# Altium schematic sheet sEMG_SignalAquisition.SchDoc
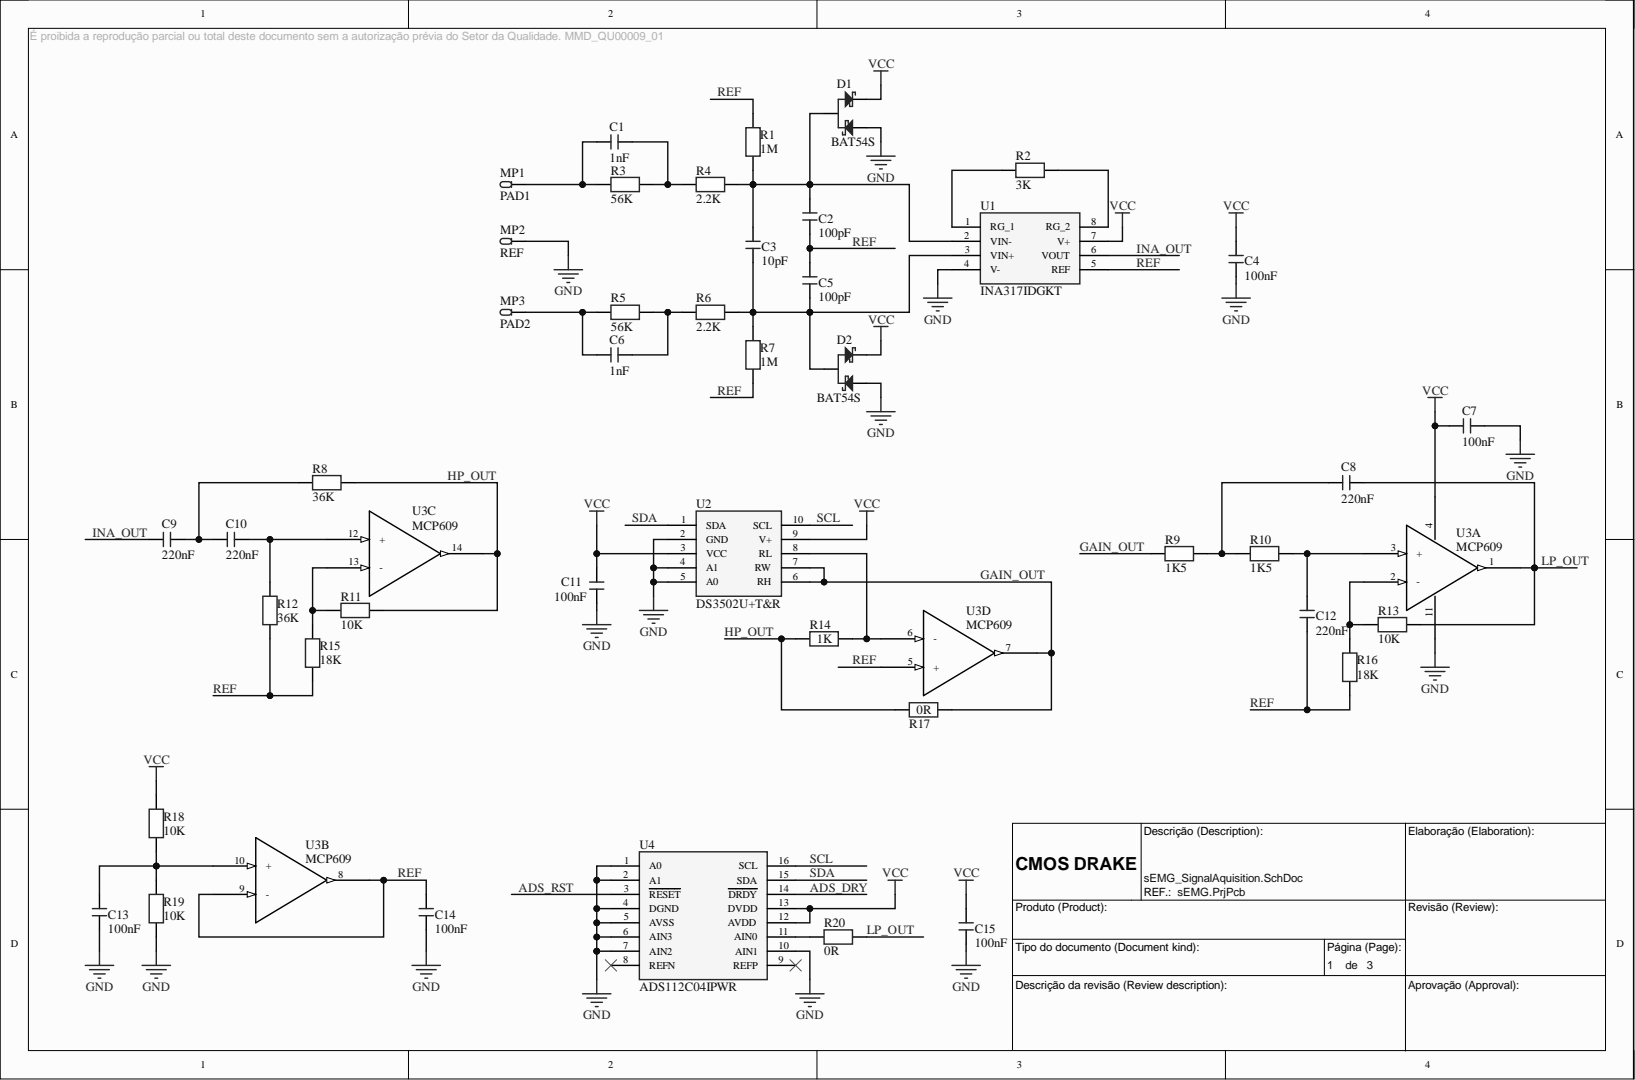
[diagram p0: sEMG_SignalAquisition.SchDoc]

## Parts
C1  1nF  [CAP0805[2012]MD]
C2  100pF  [CAP0805[2012]MD]
C3  10pF  [CAP0805[2012]MD]
C4  100nF  [CAP0603[1608]MD-BO]
C5  100pF  [CAP0805[2012]MD]
C6  1nF  [CAP0805[2012]MD]
C7  100nF  [CAP0603[1608]MD-BO]
C8  220nF  [CAP0805[2012]MD]
C9  220nF  [CAP0805[2012]MD]
C10  220nF  [CAP0805[2012]MD]
C11  100nF  [CAP0603[1608]MD-BO]
C12  220nF  [CAP0805[2012]MD]
C13  100nF  [CAP0603[1608]MD-BO]
C14  100nF  [CAP0603[1608]MD-BO]
C15  100nF  [CAP0603[1608]MD-BO]
D1  BAT54S  [SOT233MD]
D2  BAT54S  [SOT233MD]
MP1  PAD1  [MP1.6MM-BLACK]
MP2  REF  [MP1.6MM-BLACK]
MP3  PAD2  [MP1.6MM-BLACK]
R1  1M  [RES0805[2012]MD]
R2  3K  [RES0805[2012]MD]
R3  56K  [RES0805[2012]MD]
R4  2.2K  [RES0805[2012]MD]
R5  56K  [RES0805[2012]MD]
R6  2.2K  [RES0805[2012]MD]
R7  1M  [RES0805[2012]MD]
R8  36K  [RES0805[2012]MD]
R9  1K5  [RES0805[2012]MD]
R10  1K5  [RES0805[2012]MD]
R11  10K  [RES0805[2012]MD]
R12  36K  [RES0805[2012]MD]
R13  10K  [RES0805[2012]MD]
R14  1K  [RES0805[2012]MD]
R15  18K  [RES0805[2012]MD]
R16  18K  [RES0805[2012]MD]
R17  0R  [RES0603[1608]MD-BO]
R18  10K  [RES0805[2012]MD]
R19  10K  [RES0805[2012]MD]
R20  0R  [RES0603[1608]MD-BO]
U1  INA317IDGKT  [SOP65P490X110-8N]
U2  DS3502U+T&R  [SOP50P490X110-10N]
U3  MCP609  [SOP65P638X110-14N]  (parts A,B,C,D)
U4  ADS112C04IPWR  [SOP65P640X120-16N]

## Nets
GND: C4.2 C7.2 C11.2 C13.2 C14.2 C15.2 D1.1 D2.1 MP2.1 R19.2 U1.4(V-) U2.2(GND) U2.4(A1) U2.5(A0) U3.11 U4.1(A0) U4.2(A1) U4.4(DGND) U4.5(AVSS) U4.6(AIN3) U4.7(AIN2) U4.10(AIN1)
VCC: C4.1 C7.1 C11.1 C15.1 D1.2 D2.2 R18.1 U1.7(V+) U2.3(VCC) U2.9(V+) U3.4 U4.12(AVDD) U4.13(DVDD)
ADS_DRY: U4.14(DRDY)
ADS_RST: U4.3(RESET)
GAIN_OUT: R9.2 R17.2 U2.6(RH) U2.7(RW) U3.7
HP_OUT: R8.1 R11.1 R14.1 R17.1 U3.14
INA_OUT: C9.1 U1.6(VOUT)
LP_OUT: C8.2 R13.1 R20.2 U3.1
REF: C2.2 C5.1 C12.1 C14.1 R1.2 R7.1 R12.1 R15.1 R16.1 U1.5(REF) U3.5(+) U3.8 U3.9(-)
SCL: U2.10(SCL) U4.16(SCL)
SDA: U2.1(SDA) U4.15(SDA)
NetC1_1: C1.1 MP1.1 R3.1
NetC1_2: C1.2 R3.2 R4.1
NetC2_1: C2.1 C3.1 D1.3 R1.1 R4.2 U1.2(VIN-)
NetC3_2: C3.2 C5.2 D2.3 R6.2 R7.2 U1.3(VIN+)
NetC6_1: C6.1 MP3.1 R5.1
NetC6_2: C6.2 R5.2 R6.1
NetC8_1: C8.1 R9.1 R10.2
NetC9_2: C9.2 C10.2 R8.2
NetC10_1: C10.1 R12.2 U3.12(+)
NetC12_2: C12.2 R10.1 U3.3(+)
NetC13_1: C13.1 R18.2 R19.1 U3.10(+)
NetR2_1: R2.1 U1.1(RG_1)
NetR2_2: R2.2 U1.8(RG_2)
NetR11_2: R11.2 R15.2 U3.13(-)
NetR13_2: R13.2 R16.2 U3.2(-)
NetR14_2: R14.2 U2.8(RL) U3.6(-)
NetR20_1: R20.1 U4.11(AIN0)

Not connected: U4 8(REFN) 9(REFP)

## Library data of the parts
C1 C6 = SMD 0805 1nF 50V 5% NPO: CERAMIC SMD 0805 1nF 50V 5% NP0; Manufacturer 1: TDK; Part Number 1: C2012C0G1H102J; Component Kind: Standard; Library Name: Capacitors.SCHLIB
C2 C5 = SMD 0805 10pF 50V 5% C0G: CERAMIC SMD 0805 100pF 50V 5% C0G; Manufacturer 1: Johanson Dielectrics Inc; Part Number 1: 500R15N100JV4T; Component Kind: Standard; Library Name: Capacitors.SCHLIB; Manufacturer 2: Samsung Electro-Mechanics America, Inc; Manufacturer 3: Samsung Electro-Mechanics America, Inc; Manufacturer 4: Kemet; Manufacturer 5: Yageo; Manufacturer 6: AVX Corporation; Part Number 2: CL21C100JBANNNC; Part Number 3: CL21C100JBANFNC; Part Number 4: C0805C100J5GACTU; Part Number 5: CC0805JRNPO9BN100; Part Number 6: 08055A100JAT2A
C3 = SMD 0805 10pF 50V 5% C0G: CERAMIC SMD 0805 10pF 50V 5% C0G; Manufacturer 1: Johanson Dielectrics Inc; Part Number 1: 500R15N100JV4T; Component Kind: Standard; Library Name: Capacitors.SCHLIB; Manufacturer 2: Samsung Electro-Mechanics America, Inc; Manufacturer 3: Samsung Electro-Mechanics America, Inc; Manufacturer 4: Kemet; Manufacturer 5: Yageo; Manufacturer 6: AVX Corporation; Part Number 2: CL21C100JBANNNC; Part Number 3: CL21C100JBANFNC; Part Number 4: C0805C100J5GACTU; Part Number 5: CC0805JRNPO9BN100; Part Number 6: 08055A100JAT2A
C4 C7 C11 C13-C15 = SMD 0603 100nF 50V 5% X7R: CERAMIC SMD 0603 100nF 50V 5% X7R; Manufacturer 1: Samsung Electro-Mechanics America, Inc.; Part Number 1: CL10B104JB8NNNC; Component Kind: Standard; Library Name: Capacitors.SCHLIB; Manufacturer 2: Yageo; Manufacturer 3: AVX Corporation; Manufacturer 4: Murata Electronics North America; Manufacturer 5: KEMET; Part Number 2: CC0603JRX7R9BB104; Part Number 3: 06035C104JAT2A; Part Number 4: GRM188R71H104JA93D; Part Number 5: C0603C104J5RACTU
C8-C10 C12 = SMD 0805 220nF 50V 10% X7R: CERAMIC SMD 0805 220nF 50V 10% X7R; Manufacturer 1: Taiyo Yuden; Part Number 1: UMK212B7224KG-T; Component Kind: Standard; Library Name: Capacitors.SCHLIB; Manufacturer 2: Samsung Electro-Mechanics America, Inc; Manufacturer 3: Kemet; Manufacturer 4: TDK Corporation; Part Number 2: CL21B224KBFSFNE; Part Number 3: C0805C224K5RACTU; Part Number 4: C2012X7R1H224K125AA
D1 D2 = SCHOTTKY DUPLO S 30V 0.2A: Manufacturer 1: Vishay; Part Number 1: BAT54S-E3-08; Component Kind: Standard; Description: SCHOTTKY DUPLO S 30V 0.2A; Library Name: Diodes.SchLib; Manufacturer 2: MCC; Manufacturer 3: Comchip; Part Number 2: BAT54S-TP; Part Number 3: BAT54S-G
MP1-MP3 = MP PTH MULTI PURPOSE 1.6 MM BLACK: MULTI PTH PURPOSE MEASURE BLACK; Manufacturer 1: Keystone Electronics; Part Number 1: 5011; Component Kind: Standard; Library Name: Miscellaneous.SchLib
R1 R7 = SMD 0805 10K 5% 0.125W: RESISTOR SMD 0805 1M 1% 0.25W; Manufacturer 1: Yageo; Part Number 1: RC0805JR-0710KL; Component Kind: Standard; Library Name: Resistors.SCHLIB; Manufacturer 2: Samsung Electro-Mechanics America, Inc; Manufacturer 3: Stackpole Electronics Inc; Manufacturer 4: Rohm Semiconductor; Part Number 2: RC2012J103CS; Part Number 3: RMCF0805JT10K0; Part Number 4: MCR10ERTJ103
R2 = SMD 0805 10K 5% 0.125W: RESISTOR SMD 0805 3K 5% 0.25W; Manufacturer 1: Yageo; Part Number 1: RC0805JR-0710KL; Component Kind: Standard; Library Name: Resistors.SCHLIB; Manufacturer 2: Samsung Electro-Mechanics America, Inc; Manufacturer 3: Stackpole Electronics Inc; Manufacturer 4: Rohm Semiconductor; Part Number 2: RC2012J103CS; Part Number 3: RMCF0805JT10K0; Part Number 4: MCR10ERTJ103
R3 R5 = SMD 0805 10K 5% 0.125W: RESISTOR SMD 0805 56K 5% 0.25W; Manufacturer 1: Yageo; Part Number 1: RC0805JR-0710KL; Component Kind: Standard; Library Name: Resistors.SCHLIB; Manufacturer 2: Samsung Electro-Mechanics America, Inc; Manufacturer 3: Stackpole Electronics Inc; Manufacturer 4: Rohm Semiconductor; Part Number 2: RC2012J103CS; Part Number 3: RMCF0805JT10K0; Part Number 4: MCR10ERTJ103
R4 R6 = SMD 0805 10K 5% 0.125W: RESISTOR SMD 0805 2.2K 5% 0.25W; Manufacturer 1: Yageo; Part Number 1: RC0805JR-0710KL; Component Kind: Standard; Library Name: Resistors.SCHLIB; Manufacturer 2: Samsung Electro-Mechanics America, Inc; Manufacturer 3: Stackpole Electronics Inc; Manufacturer 4: Rohm Semiconductor; Part Number 2: RC2012J103CS; Part Number 3: RMCF0805JT10K0; Part Number 4: MCR10ERTJ103
R8 R12 = SMD 0805 10K 5% 0.125W: RESISTOR SMD 0805 36K 1% 0.25W; Manufacturer 1: Yageo; Part Number 1: RC0805JR-0710KL; Component Kind: Standard; Library Name: Resistors.SCHLIB; Manufacturer 2: Samsung Electro-Mechanics America, Inc; Manufacturer 3: Stackpole Electronics Inc; Manufacturer 4: Rohm Semiconductor; Part Number 2: RC2012J103CS; Part Number 3: RMCF0805JT10K0; Part Number 4: MCR10ERTJ103
R9 R10 = SMD 0805 10K 5% 0.125W: RESISTOR SMD 0805 1K5 1% 0.25W; Manufacturer 1: Yageo; Part Number 1: RC0805JR-0710KL; Component Kind: Standard; Library Name: Resistors.SCHLIB; Manufacturer 2: Samsung Electro-Mechanics America, Inc; Manufacturer 3: Stackpole Electronics Inc; Manufacturer 4: Rohm Semiconductor; Part Number 2: RC2012J103CS; Part Number 3: RMCF0805JT10K0; Part Number 4: MCR10ERTJ103
R11 R13 R18 R19 = SMD 0805 10K 5% 0.125W: RESISTOR SMD 0805 10K 1% 0.25W; Manufacturer 1: Yageo; Part Number 1: RC0805JR-0710KL; Component Kind: Standard; Library Name: Resistors.SCHLIB; Manufacturer 2: Samsung Electro-Mechanics America, Inc; Manufacturer 3: Stackpole Electronics Inc; Manufacturer 4: Rohm Semiconductor; Part Number 2: RC2012J103CS; Part Number 3: RMCF0805JT10K0; Part Number 4: MCR10ERTJ103
R14 = SMD 0805 10K 5% 0.125W: RESISTOR SMD 0805 1K 5% 0.25W; Manufacturer 1: Yageo; Part Number 1: RC0805JR-0710KL; Component Kind: Standard; Library Name: Resistors.SCHLIB; Manufacturer 2: Samsung Electro-Mechanics America, Inc; Manufacturer 3: Stackpole Electronics Inc; Manufacturer 4: Rohm Semiconductor; Part Number 2: RC2012J103CS; Part Number 3: RMCF0805JT10K0; Part Number 4: MCR10ERTJ103
R15 R16 = SMD 0805 10K 5% 0.125W: RESISTOR SMD 0805 18K 1% 0.25W; Manufacturer 1: Yageo; Part Number 1: RC0805JR-0710KL; Component Kind: Standard; Library Name: Resistors.SCHLIB; Manufacturer 2: Samsung Electro-Mechanics America, Inc; Manufacturer 3: Stackpole Electronics Inc; Manufacturer 4: Rohm Semiconductor; Part Number 2: RC2012J103CS; Part Number 3: RMCF0805JT10K0; Part Number 4: MCR10ERTJ103
R17 R20 = SMD 0603 0R 1A 0.1W: RESISTOR SMD 0603 0R 0.1W; Manufacturer 1: Vishay; Part Number 1: RC0603JR-070RL; Component Kind: Standard; Library Name: Resistors.SCHLIB; Manufacturer 2: Stackpole Electronics Inc.; Manufacturer 3: Bourns Inc.; Manufacturer 4: TE Connectivity Passive Product; Part Number 2: RMCF0603ZT0R00; Part Number 3: CR0603-J/-000ELF; Part Number 4: CRG0603ZR
U1 = INA317IDGKT: Instrumentation Amplifiers Micro-Power (50 uA), Zero-Drift, Rail-to-Rail-Out Instrumentation Amplifier 8-VSSOP -40 to 125; Manufacturer_Name: Texas Instruments; Geometry.Height: 1.1mm; Component Kind: Standard; Library Name: INA317IDGKT.SchLib; Manufacturer_Part_Number: INA317IDGKT; Mouser Part Number: 595-INA317IDGKT; Mouser Price/Stock: https://www.mouser.co.uk/ProductDetail/Texas-Instruments/INA317IDGKT?qs=W0yvOO0ixfEwUOWvns546g%3D%3D
U2 = DS3502U+T&R: High-Voltage NV I?C Potentiometer; Manufacturer_Name: Analog Devices; Geometry.Height: 1.1mm; Component Kind: Standard; Datasheet Link: https://www.analog.com/DS3502/datasheet; Library Name: DS3502U+T&R.SchLib; Manufacturer_Part_Number: DS3502U+T&R; Mouser Part Number: 700-DS3502UT&R; Mouser Price/Stock: https://www.mouser.co.uk/ProductDetail/Analog-Devices-Maxim-Integrated/DS3502U%2bTR?qs=CDqwynd4ZNqeOH9nd1WNwQ%3D%3D
U4 = ADS112C04IPWR: 16-Bit 2kSPS 4-Ch Low-Power Delta-Sigma ADC With PGA, Voltage Reference, 2x IDACs, and I2C Interface; Manufacturer_Name: Texas Instruments; Geometry.Height: 1.2mm; Component Kind: Standard; Datasheet Link: http://www.ti.com/lit/gpn/ads112c04; Library Name: ADS112C04IPWR.SchLib; Manufacturer_Part_Number: ADS112C04IPWR; Mouser Part Number: 595-ADS112C04IPWR; Mouser Price/Stock: https://www.mouser.co.uk/ProductDetail/Texas-Instruments/ADS112C04IPWR?qs=55YtniHzbhCnCkXA6WKV4g%3D%3D
U3 = MCP609: Component Kind: Standard; Library Name: MCP609_edited.SchLib
